# Altium schematic sheet FMC.SchDoc(FMC)
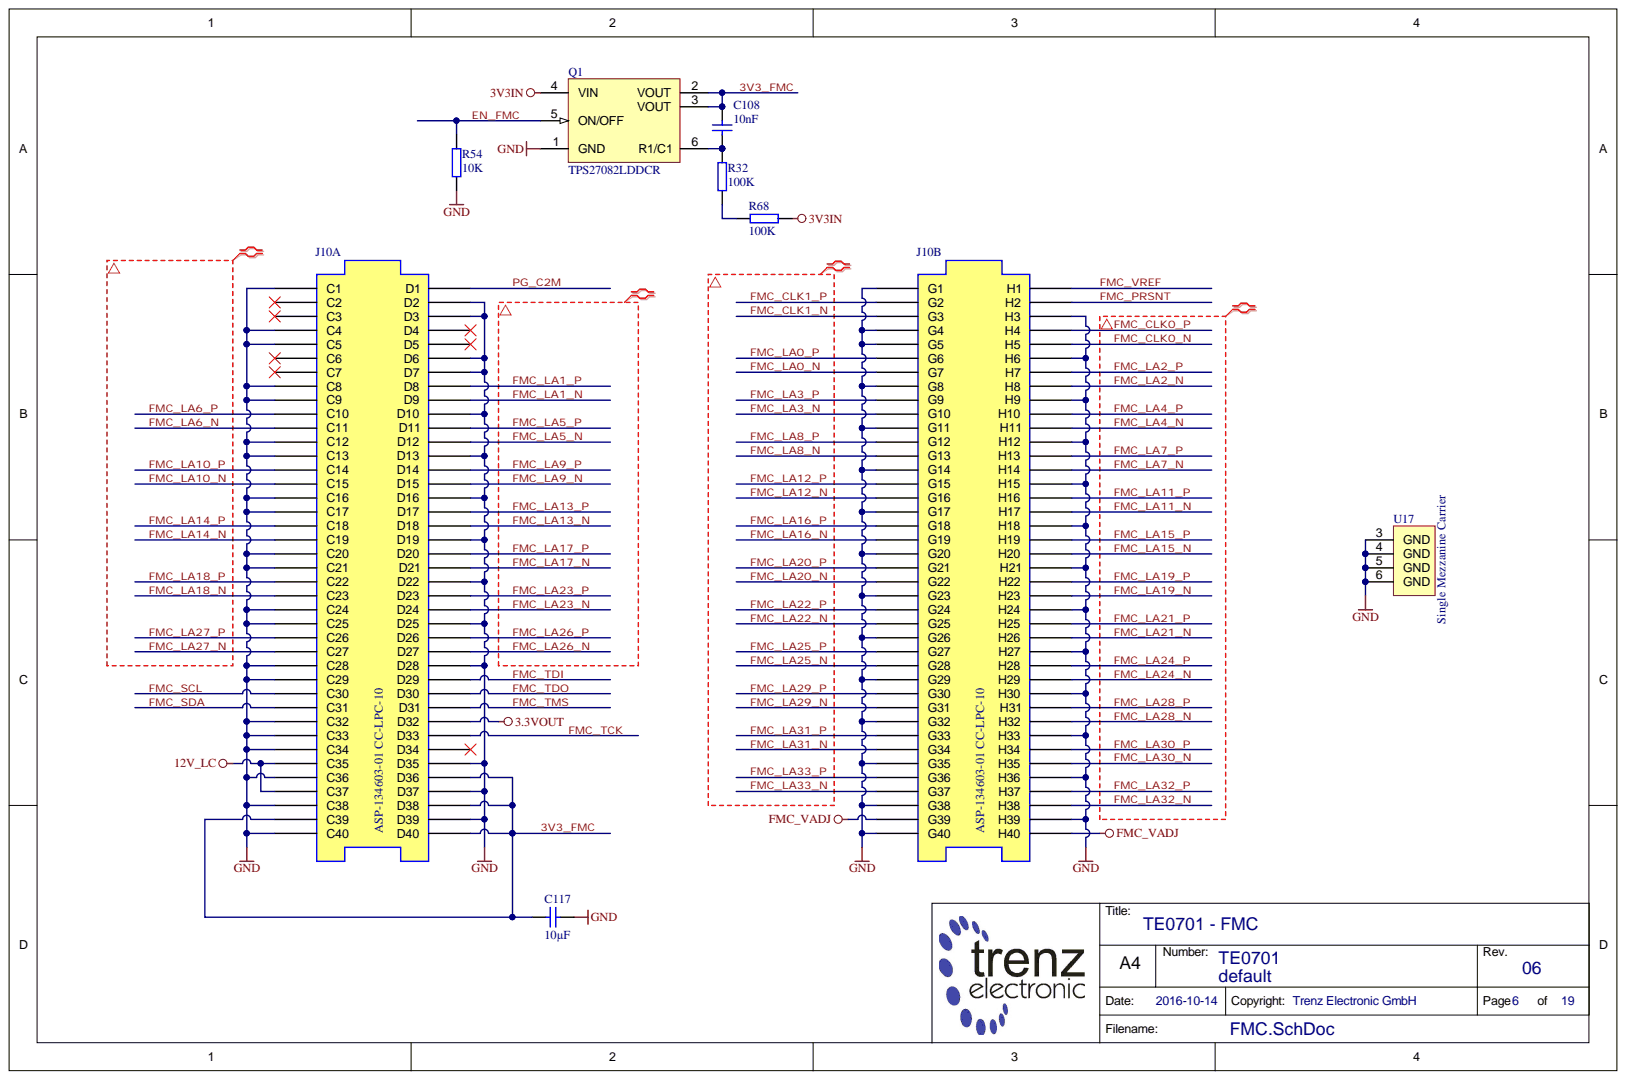
[diagram p0: FMC.SchDoc]

## Parts
C108  10nF  [CAPC1005X55N]
C117  10µF  [CAPC2012X135N]
J10  ASP-134603-01 CC-LPC-10  [ASP-134603-01]  (parts A,B)
Q1  TPS27082LDDCR  [SOT95P280X110-6N]
R32  100K  [RESC1005X40N]
R54  10K  [RESC1005X40N]
R68  100K  [RESC1005X40N]
U17  Single Mezzanine Carrier  Case: Single  [FMC-MM-Single-Base]

## Nets
3.3VOUT: J10.D32
3V3IN: Q1.4(VIN) R68.2
12V_LC: J10.C35 J10.C37
FMC_VADJ: J10.G39 J10.H40
GND: C117.1 J10.C1 J10.C4 J10.C5 J10.C8 J10.C9 J10.C12 J10.C13 J10.C16 J10.C17 J10.C20 J10.C21 J10.C24 J10.C25 J10.C28 J10.C29 J10.C32 J10.C33 J10.C34 J10.C36 J10.C38 J10.C40 J10.D2 J10.D3 J10.D6 J10.D7 J10.D10 J10.D13 J10.D16 J10.D19 J10.D22 J10.D25 J10.D28 J10.D35 J10.D37 J10.D39 J10.G1 J10.G4 J10.G5 J10.G8 J10.G11 J10.G14 J10.G17 J10.G20 J10.G23 J10.G26 J10.G29 J10.G32 J10.G35 J10.G38 J10.G40 J10.H3 J10.H6 J10.H9 J10.H12 J10.H15 J10.H18 J10.H21 J10.H24 J10.H27 J10.H30 J10.H33 J10.H36 J10.H39 Q1.1(GND) R54.1 U17.3(GND) U17.4(GND) U17.5(GND) U17.6(GND)
3V3_FMC: C108.1 C117.2 J10.C39 J10.D36 J10.D38 J10.D40 Q1.2(VOUT) Q1.3(VOUT)
EN_FMC: Q1.5(ON/OFF) R54.2
FMC_CLK0_N: J10.H5
FMC_CLK0_P: J10.H4
FMC_CLK1_N: J10.G3
FMC_CLK1_P: J10.G2
FMC_LA0_N: J10.G7
FMC_LA0_P: J10.G6
FMC_LA1_N: J10.D9
FMC_LA1_P: J10.D8
FMC_LA2_N: J10.H8
FMC_LA2_P: J10.H7
FMC_LA3_N: J10.G10
FMC_LA3_P: J10.G9
FMC_LA4_N: J10.H11
FMC_LA4_P: J10.H10
FMC_LA5_N: J10.D12
FMC_LA5_P: J10.D11
FMC_LA6_N: J10.C11
FMC_LA6_P: J10.C10
FMC_LA7_N: J10.H14
FMC_LA7_P: J10.H13
FMC_LA8_N: J10.G13
FMC_LA8_P: J10.G12
FMC_LA9_N: J10.D15
FMC_LA9_P: J10.D14
FMC_LA10_N: J10.C15
FMC_LA10_P: J10.C14
FMC_LA11_N: J10.H17
FMC_LA11_P: J10.H16
FMC_LA12_N: J10.G16
FMC_LA12_P: J10.G15
FMC_LA13_N: J10.D18
FMC_LA13_P: J10.D17
FMC_LA14_N: J10.C19
FMC_LA14_P: J10.C18
FMC_LA15_N: J10.H20
FMC_LA15_P: J10.H19
FMC_LA16_N: J10.G19
FMC_LA16_P: J10.G18
FMC_LA17_N: J10.D21
FMC_LA17_P: J10.D20
FMC_LA18_N: J10.C23
FMC_LA18_P: J10.C22
FMC_LA19_N: J10.H23
FMC_LA19_P: J10.H22
FMC_LA20_N: J10.G22
FMC_LA20_P: J10.G21
FMC_LA21_N: J10.H26
FMC_LA21_P: J10.H25
FMC_LA22_N: J10.G25
FMC_LA22_P: J10.G24
FMC_LA23_N: J10.D24
FMC_LA23_P: J10.D23
FMC_LA24_N: J10.H29
FMC_LA24_P: J10.H28
FMC_LA25_N: J10.G28
FMC_LA25_P: J10.G27
FMC_LA26_N: J10.D27
FMC_LA26_P: J10.D26
FMC_LA27_N: J10.C27
FMC_LA27_P: J10.C26
FMC_LA28_N: J10.H32
FMC_LA28_P: J10.H31
FMC_LA29_N: J10.G31
FMC_LA29_P: J10.G30
FMC_LA30_N: J10.H35
FMC_LA30_P: J10.H34
FMC_LA31_N: J10.G34
FMC_LA31_P: J10.G33
FMC_LA32_N: J10.H38
FMC_LA32_P: J10.H37
FMC_LA33_N: J10.G37
FMC_LA33_P: J10.G36
FMC_PRSNT: J10.H2
FMC_SCL: J10.C30
FMC_SDA: J10.C31
FMC_TCK: J10.D33
FMC_TDI: J10.D29
FMC_TDO: J10.D30
FMC_TMS: J10.D31
FMC_VREF: J10.H1
PG_C2M: J10.D1
NetC108_2: C108.2 Q1.6(R1/C1) R32.1
NetR32_2: R32.2 R68.1
N00243:
N00244:
N00245:
N00246:

Not connected: J10 C2 C3 C6 C7 D4 D5 D34

## Library data of the parts
C108 = 22516: KON 10nF 50V X7R SMD 10%; Database Table Name: C_1005_0402; Mixed: SMT; Preferred: Yes; Value: 10n; Voltage: 16V; AN: 22516; Case: 1005 (0402); Component Kind: Standard; Library Name: TE.DbLib; Technology: X7R; Tolerance: 10%
C117 = 24380: KON 10µF 16V X7R SMD 10%; Database Table Name: C_2012_0805; Mixed: SMT; Preferred: Yes; Value: 10u; AN: 24380; Case: 2012 (0805); Component Kind: Standard; Library Name: TE.DbLib; Technology: X7R; Tolerance: 10%; Voltage: 16V
J10 = 24996: ASP-134603-01 female CC-LPC-10; Database Table Name: CON_B2B; Mixed: SMT; Trenz-Stock: Yes; AN: 24996; Component Kind: Standard; H-ART: ASP-134603-01; Library Name: TE.DbLib; HelpURL: B:\AD\adlib\datasheet\ASP-134603-01
Q1 = 25004: Database Table Name: SWITCH; Mixed: SMT; Preferred: Yes; AN: 25004; Case: SOT23-6; Component Kind: Standard; Description: TPS27082LDDCR; H-ART: TPS27082LDDCR; Library Name: TE.DbLib; Trenz-Stock: Yes; HelpURL: B:\AD\adlib\datasheet\TPS27082L
R32 R68 = 22558: WID SMD 100K 1% 0,063W; Database Table Name: R_1005_0402; Mixed: SMT; Preferred: Yes; AN: 22558; Case: 1005 (0402); Component Kind: Standard; Library Name: TE.DbLib; Technology: TK100; Tolerance: 1%; Value: 100K
R54 = 22750: WID SMD 10K 1% 0,063W; Database Table Name: R_1005_0402; Mixed: SMT; Preferred: Yes; AN: 22750; Case: 1005 (0402); Component Kind: Standard; Library Name: TE.DbLib; Technology: TK100; Tolerance: 1%; Value: 10K
U17 = 25453: FMC-MM-Single PCB Baseboard Snap; AN: 25453; Component Kind: Standard; Database Table Name: PCB; Library Name: TE.DbLib; HelpURL: B:\AD\adlib\datasheet\FMC Mezzanine Module
